# Altium schematic sheet USBC.SchDoc(USBC)
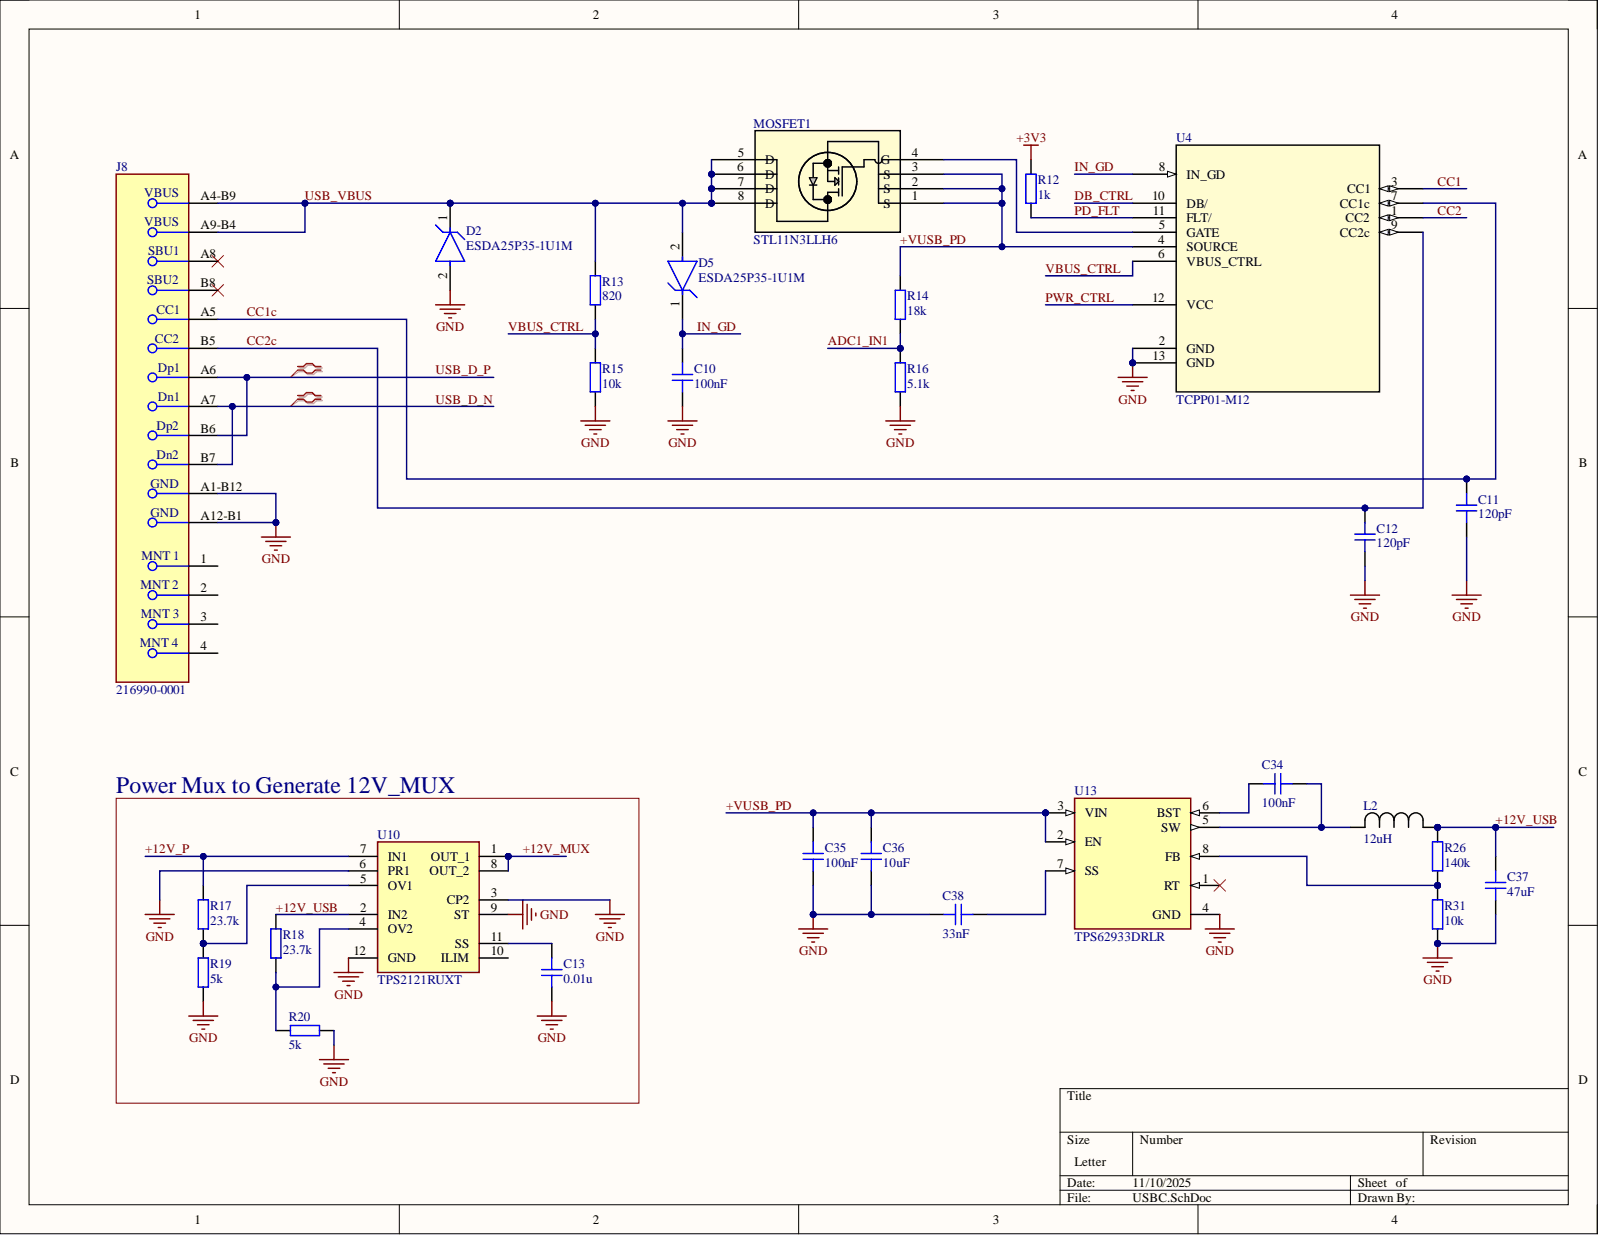
[diagram p0: USBC.SchDoc]

## Parts
C10  100nF
C11  120pF
C12  120pF
C13  0.01u
C34  100nF
C35  100nF
C36  10uF
C37  47uF
C38  33nF
D2  ESDA25P35-1U1M
D5  ESDA25P35-1U1M
J8  216990-0001
L2  12uH
MOSFET1  STL11N3LLH6
R12  1k
R13  820
R14  18k
R15  10k
R16  5.1k
R17  23.7k
R18  23.7k
R19  5k
R20  5k
R26  140k
R31  10k
U4  TCPP01-M12
U10  TPS2121RUXT
U13  TPS62933DRLR

## Nets
+3V3: R12.1
GND: C10.1 C11.1 C12.1 C13.2 C35.2 C36.2 C37.1 C38.2 D2.2 J8.A1-B12 J8.A12-B1 R15.1 R16.1 R19.1 R20.1 R31.1 U4.2(GND) U4.13(GND) U10.3(CP2) U10.6(PR1) U10.9(ST) U10.12(GND) U13.4(GND)
+12V_MUX: U10.1(OUT_1) U10.8(OUT_2)
+12V_P: R17.2 U10.7(IN1)
+12V_USB: C37.2 L2.1 R18.2 R26.2 U10.2(IN2)
+VUSB_PD: C35.1 C36.1 MOSFET1.1(S) MOSFET1.2(S) MOSFET1.3(S) R14.2 U4.4(SOURCE) U13.2(EN) U13.3(VIN)
ADC1_IN1: R14.1 R16.2
CC1: U4.3(CC1)
CC1c: C11.2 J8.A5 U4.7(CC1c)
CC2: U4.1(CC2)
CC2c: C12.2 J8.B5 U4.9(CC2c)
DB_CTRL: U4.10(DB/)
IN_GD: C10.2 D5.1 U4.8(IN_GD)
PD_FLT: R12.2 U4.11(FLT/)
PWR_CTRL: U4.12(VCC)
USB_D_N: J8.A7 J8.B7
USB_D_P: J8.A6 J8.B6
USB_VBUS: D2.1 D5.2 J8.A4-B9 J8.A9-B4 MOSFET1.5(D) MOSFET1.6(D) MOSFET1.7(D) MOSFET1.8(D) R13.2
VBUS_CTRL: R13.1 R15.2 U4.6(VBUS_CTRL)
NetC13_1: C13.1 U10.11(SS)
NetC34_1: C34.1 U13.6(BST)
NetC34_2: C34.2 L2.2 U13.5(SW)
NetC38_1: C38.1 U13.7(SS)
NetMOSFET1_4: MOSFET1.4(G) U4.5(GATE)
NetR17_1: R17.1 R19.2 U10.5(OV1)
NetR18_1: R18.1 R20.2 U10.4(OV2)
NetR26_1: R26.1 R31.2 U13.8(FB)

Not connected: J8 1 2 3 4 A8 B8; U10 10(ILIM); U13 1(RT)

## Library data of the parts
C10 C13 C34-C38 = CAP_0603: Capacitor SMD 0603; Component Kind: Standard; Library Name: Schematic-Lib-PhilsLab (1).SchLib
C11 C12 = CAP_0402: Capacitor SMD 0402; Component Kind: Standard; Library Name: Schematic-Lib-PhilsLab (1).SchLib
D2 D5 = CMP-12225-000030-1: TVS DIODE 22V 41V 1610; Manufacturer: STMicroelectronics; Breakdown Voltage: 23.3V; Clamping Voltage: 39V; Direction: Unidirectional; Max Junction Temp: +150°C; Number Of Channels: 1; Peak Pulse Current: 35A; Peak Pulse Power: 1400W; Standoff Height: 0.02mm; Automotive: No; Category: Diode; Component Kind: Standard; ComponentLink2Description: Datasheet; ComponentLink2URL: https://www.st.com/resource/en/datasheet/esda25p35-1u1m.pdf; Device Class L1: Circuit Protection; Device Class L2: TVS Diodes; Device Class L3: unset; Digikey Description: TVS DIODE 22V 41V 1610; Height: 0.6mm; Lead Free: Yes; Library Name: Altium Content Vault; Manufacturer Part Number: ESDA25P35-1U1M; Mouser Description: ESDA Series 1400 W 25 V Uni-Directional Surface Mount TVS Diode - QFN-1610; Octopart: https://octopart.com/esda25p35-1u1m-stmicroelectronics-75302699; Package: QFN_1610; Reverse Standoff Voltage: 22V; RoHS: TRUE; Temperature: -55°C to +150°C; Temperature Range High: +150°C; Temperature Range Low: -55°C
J8 = CMP-04767-000014-1: CONN RCP USB2.0 TYP C 24P SMD RA; Component Kind: Standard; Library Name: Altium Content Vault
L2 = SRR1208-120ML: No Description Available; Manufacturer_Name: Bourns Electronics; Component Kind: Standard; Library Name: SRR1208-120ML.SchLib; Manufacturer_Part_Number: SRR1208-120ML; Copyright: Copyright (C) 2025 Ultra Librarian. All rights reserved.
MOSFET1 = STL11N3LLH6: N-channel 30 V, 6 mOhm typ., 11 A STripFET H6 Power MOSFET in a PowerFLAT(TM) 3.3 x 3.3 package; Manufacturer_Name: STMicroelectronics; Component Kind: Standard; Datasheet: https://www.st.com/resource/en/datasheet/stl11n3llh6.pdf; Library Name: STL11N3LLH6.SchLib; Manufacturer_Part_Number: STL11N3LLH6; RefDes: RefDes; Type: Type; Copyright: Copyright (C) 2025 Ultra Librarian. All rights reserved.
R12-R20 R26 R31 = RES_0603: Resistor SMD 0603; Component Kind: Standard; Library Name: Schematic-Lib-PhilsLab (1).SchLib
U4 = TCPP01-M12: USB Type-C Power Delivery interface for Sink application up to 100 W; Manufacturer_Name: STMicroelectronics; Component Kind: Standard; Datasheet: https://www.st.com/resource/en/datasheet/tcpp01-m12.pdf; Library Name: TCPP01-M12.SchLib; Manufacturer_Part_Number: TCPP01-M12; RefDes: RefDes; Type: Type; Copyright: Copyright (C) 2025 Ultra Librarian. All rights reserved.
U10 = CMP-031-00038-2: 2.7-22V Power Mux; Manufacturer_Name: Texas Instruments; Arrow Part Number: TPS2121RUXT; Arrow Price/Stock: https://www.arrow.com/en/products/tps2121ruxt/texas-instruments?region=nac; Component Kind: Standard; Datasheet Link: https://www.arrow.com/en/products/tps2121ruxt/texas-instruments; Design Item ID: TPS2121RUXT; Height: 1mm; Manufacturer_Part_Number: TPS2121RUXT; Mouser Part Number: 595-TPS2121RUXT; Mouser Price/Stock: https://www.mouser.co.uk/ProductDetail/Texas-Instruments/TPS2121RUXT?qs=l7cgNqFNU1i6abJCbO5ulQ%3D%3D; Source: SamacSys.SchLib; Library Name: Solar Gators
U13 = TPS62933DRLR: 3.8-V to 30-V, 3-A, 200-kHz to 2.2-MHz, low-IQ synchronous buck converter in SOT-583 package; MF: Texas Instruments; Check_prices: https://www.snapeda.com/parts/TPS62933DRLR/Texas+Instruments/view-part/?ref=eda; Component Kind: Standard; Library Name: TPS62933DRLR.IntLib; MP: TPS62933DRLR; Package: SOT-583 Texas Instruments; SnapEDA_Link: https://www.snapeda.com/parts/TPS62933DRLR/Texas+Instruments/view-part/?ref=snap
